# Altium schematic sheet SLA-3000-FPC_Page02.SchDoc
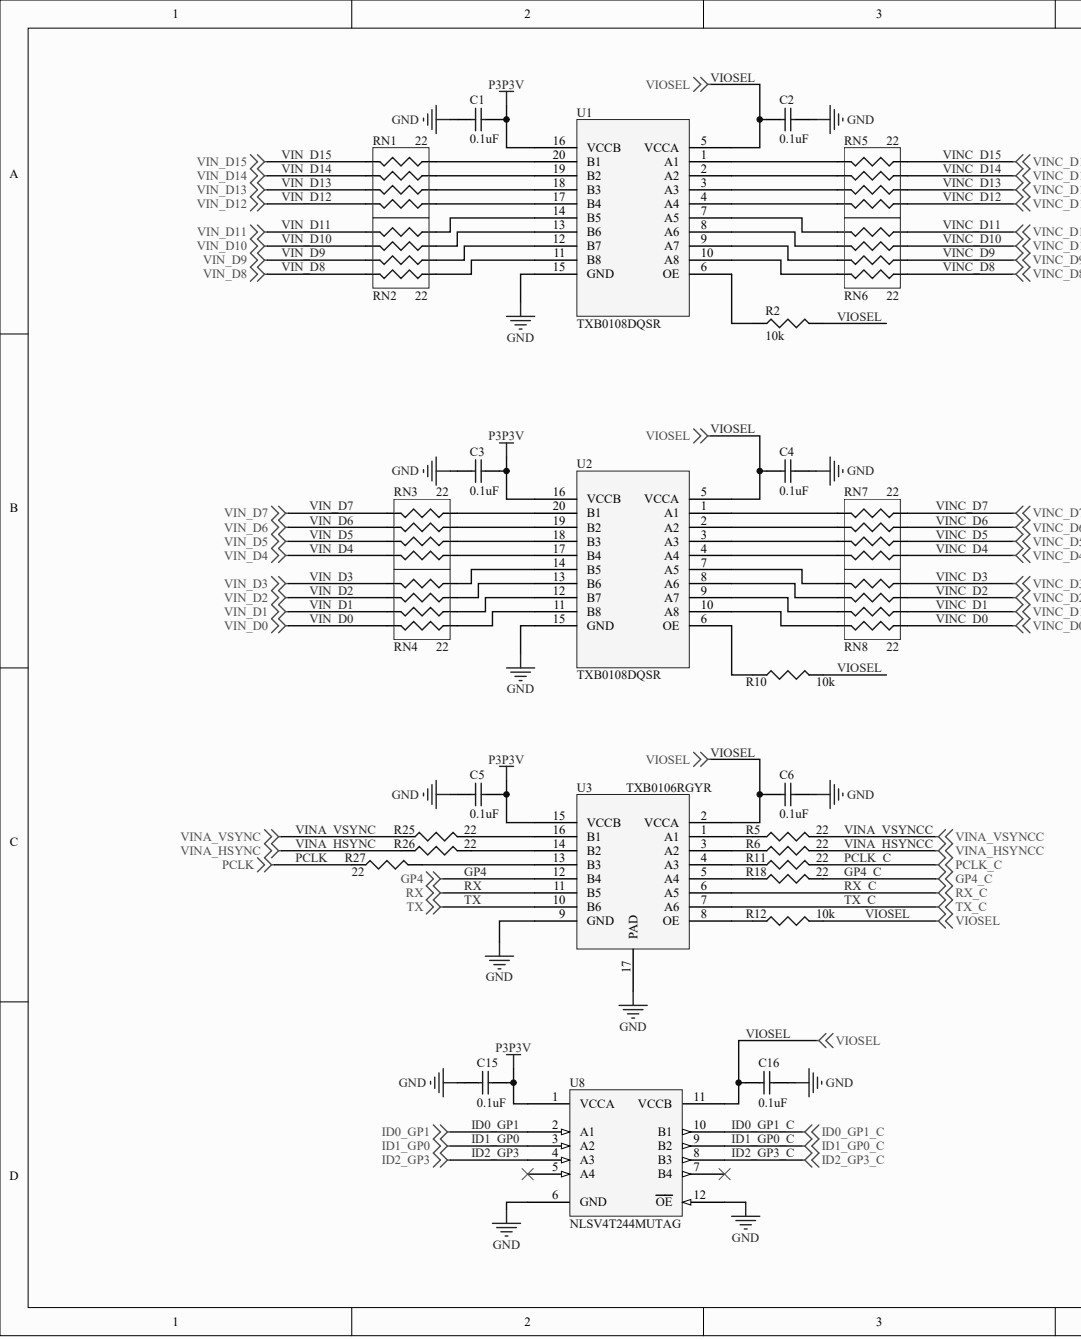
[diagram p0: SLA-3000-FPC_Page02.SchDoc, left]
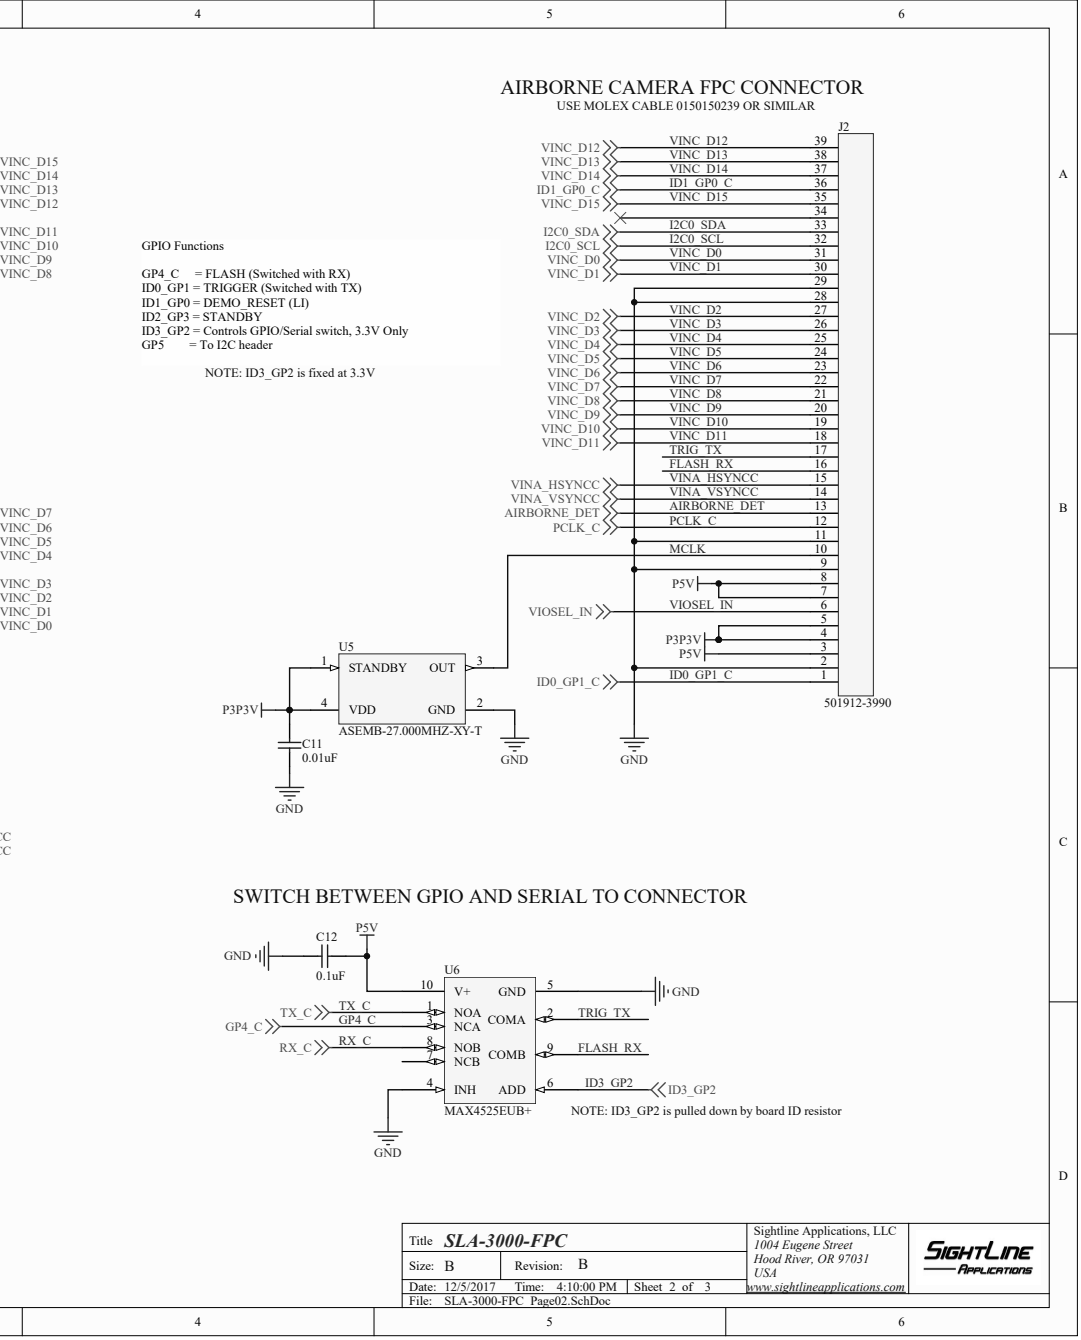
[diagram p1: SLA-3000-FPC_Page02.SchDoc, right]

## Parts
C1  0.1uF  [0402]
C2  0.1uF  [0402]
C3  0.1uF  [0402]
C4  0.1uF  [0402]
C5  0.1uF
C6  0.1uF
C11  0.01uF
C12  0.1uF  [0402]
C15  0.1uF
C16  0.1uF
J2  501912-3990
R2  10k
R5  22  (value not drawn)
R6  22  (value not drawn)
R10  10k  [0402]
R11  22  (value not drawn)
R12  10k
R18  22  (value not drawn)
R25  22  (value not drawn)
R26  22  (value not drawn)
R27  22  (value not drawn)
RN1  22  (value not drawn)
RN2  22  (value not drawn)
RN3  22  (value not drawn)
RN4  22  (value not drawn)
RN5  22  (value not drawn)
RN6  22  (value not drawn)
RN7  22  (value not drawn)
RN8  22  (value not drawn)
U1  TXB0108DQSR
U2  TXB0108DQSR
U3  TXB0106RGYR
U5  ASEMB-27.000MHZ-XY-T
U6  MAX4525EUB+
U8  NLSV4T244MUTAG

## Nets
GND: C1.1 C2.1 C3.1 C4.1 C5.1 C6.1 C11.1 C12.1 C15.1 C16.1 J2.2 J2.9 J2.11 J2.28 J2.29 U1.15(GND) U2.15(GND) U3.9(GND) U3.17(PAD) U5.2(GND) U6.4(INH) U6.5(GND) U8.6(GND) U8.12(OE)
P3P3V: C1.2 C3.2 C5.2 C11.2 C15.2 J2.4 J2.5 U1.16(VCCB) U2.16(VCCB) U3.15(VCCB) U5.1(STANDBY) U5.4(VDD) U8.1(VCCA)
P5V: C12.2 J2.3 J2.7 J2.8 U6.10(V+)
AIRBORNE_DET: J2.13
FLASH_RX: J2.16 U6.9(COMB)
GP4: U3.12(B4)
GP4_C: R18.2 U6.3(NCA)
I2C0_SCL: J2.32
I2C0_SDA: J2.33
ID0_GP1: U8.2(A1)
ID0_GP1_C: J2.1 U8.10(B1)
ID1_GP0: U8.3(A2)
ID1_GP0_C: J2.36 U8.9(B2)
ID2_GP3: U8.4(A3)
ID2_GP3_C: U8.8(B3)
ID3_GP2: U6.6(ADD)
MCLK: J2.10 U5.3(OUT)
PCLK: R27.1
PCLK_C: J2.12 R11.2
RX: U3.11(B5)
RX_C: U3.6(A5) U6.8(NOB)
TRIG_TX: J2.17 U6.2(COMA)
TX: U3.10(B6)
TX_C: U3.7(A6) U6.1(NOA)
VIN_D0: RN4.1
VIN_D1: RN4.2
VIN_D2: RN4.3
VIN_D3: RN4.4
VIN_D4: RN3.1
VIN_D5: RN3.2
VIN_D6: RN3.3
VIN_D7: RN3.4
VIN_D8: RN2.1
VIN_D9: RN2.2
VIN_D10: RN2.3
VIN_D11: RN2.4
VIN_D12: RN1.1
VIN_D13: RN1.2
VIN_D14: RN1.3
VIN_D15: RN1.4
VINA_HSYNC: R26.1
VINA_HSYNCC: J2.15 R6.2
VINA_VSYNC: R25.1
VINA_VSYNCC: J2.14 R5.2
VINC_D0: J2.31 RN8.8
VINC_D1: J2.30 RN8.7
VINC_D2: J2.27 RN8.6
VINC_D3: J2.26 RN8.5
VINC_D4: J2.25 RN7.8
VINC_D5: J2.24 RN7.7
VINC_D6: J2.23 RN7.6
VINC_D7: J2.22 RN7.5
VINC_D8: J2.21 RN6.8
VINC_D9: J2.20 RN6.7
VINC_D10: J2.19 RN6.6
VINC_D11: J2.18 RN6.5
VINC_D12: J2.39 RN5.8
VINC_D13: J2.38 RN5.7
VINC_D14: J2.37 RN5.6
VINC_D15: J2.35 RN5.5
VIOSEL: C2.2 C4.2 C6.2 C16.2 R2.2 R10.2 R12.2 U1.5(VCCA) U2.5(VCCA) U3.2(VCCA) U8.11(VCCB)
VIOSEL_IN: J2.6
NetR2_1: R2.1 U1.6(OE)
NetR5_1: R5.1 U3.1(A1)
NetR6_1: R6.1 U3.3(A2)
NetR10_1: R10.1 U2.6(OE)
NetR11_1: R11.1 U3.4(A3)
NetR12_1: R12.1 U3.8(OE)
NetR18_1: R18.1 U3.5(A4)
NetR25_2: R25.2 U3.16(B1)
NetR26_2: R26.2 U3.14(B2)
NetR27_2: R27.2 U3.13(B3)
NetRN1_5: RN1.5 U1.20(B1)
NetRN1_6: RN1.6 U1.19(B2)
NetRN1_7: RN1.7 U1.18(B3)
NetRN1_8: RN1.8 U1.17(B4)
NetRN2_5: RN2.5 U1.14(B5)
NetRN2_6: RN2.6 U1.13(B6)
NetRN2_7: RN2.7 U1.12(B7)
NetRN2_8: RN2.8 U1.11(B8)
NetRN3_5: RN3.5 U2.20(B1)
NetRN3_6: RN3.6 U2.19(B2)
NetRN3_7: RN3.7 U2.18(B3)
NetRN3_8: RN3.8 U2.17(B4)
NetRN4_5: RN4.5 U2.14(B5)
NetRN4_6: RN4.6 U2.13(B6)
NetRN4_7: RN4.7 U2.12(B7)
NetRN4_8: RN4.8 U2.11(B8)
NetRN5_1: RN5.1 U1.4(A4)
NetRN5_2: RN5.2 U1.3(A3)
NetRN5_3: RN5.3 U1.2(A2)
NetRN5_4: RN5.4 U1.1(A1)
NetRN6_1: RN6.1 U1.10(A8)
NetRN6_2: RN6.2 U1.9(A7)
NetRN6_3: RN6.3 U1.8(A6)
NetRN6_4: RN6.4 U1.7(A5)
NetRN7_1: RN7.1 U2.4(A4)
NetRN7_2: RN7.2 U2.3(A3)
NetRN7_3: RN7.3 U2.2(A2)
NetRN7_4: RN7.4 U2.1(A1)
NetRN8_1: RN8.1 U2.10(A8)
NetRN8_2: RN8.2 U2.9(A7)
NetRN8_3: RN8.3 U2.8(A6)
NetRN8_4: RN8.4 U2.7(A5)

Not connected: J2 34; U6 7(NCB); U8 5(A4) 7(B4)

## Library data of the parts
C1-C4 C12 = (no symbol name): MfgPartNo: C1005X7R1E104K; SLA Part No: SL00150; Rated Voltage: 25V; Tolerance: 10%; Type: Ceramic - X7R; Mfg: TDK; Value: 0.1uF; Capacitance: 0.1uF; Package: 402
C5 C6 C15 C16 = (no symbol name): MfgPartNo: C1005X7R1E104K; SLA Part No: SL00150; Type: Ceramic - X7R; Mfg: TDK; Capacitance: 0.1uF; Package: 402
C11 = (no symbol name): MfgPartNo: GRM155R71C103KA01D; SLA Part No: SL00317; Mfg: Murata; Type: Ceramic - X7R; Capacitance: 0.01uF; Package: 402
J2 = (no symbol name): SLA Part No: SL00670; Gender: Female; Mfg: Molex; MfgPartNo: 501912-3990; Mounting: SMT
R2 R12 = (no symbol name): MfgPartNo: ERJ-2RKF1002X; SLA Part No: SL00225; Mfg: Panasonic; Package: 402; Resistance: 10k
R5 R6 R11 R18 R25-R27 = (no symbol name): MfgPartNo: ERJ-2RKF22R0X; SLA Part No: SL00335; Mfg: Panasonic; Package: 402; Resistance: 22
R10 = (no symbol name): MfgPartNo: ERJ-2RKF1002X; SLA Part No: SL00225; Mfg: Panasonic; Power (W): 1/10; Tolerance: 1%; Value: 10k; Package: 402; Resistance: 10k
RN1-RN8 = (no symbol name): MfgPartNo: YC124-JR-0722RL; SLA Part No: SL00045; Mfg: Yageo; Power: 1/16; Tolerance: 5%; Package: 4x0402; Resistance: 22
U1 U2 = (no symbol name): SLA Part No: SL00416; Mfg: Texas Instruments; HelpURL: http://www.ti.com/lit/ds/symlink/txb0108.pdf; MfgPartNo: TXB0108DQSR; Package: 20-USON
U3 = (no symbol name): SLA Part No: SL00262; Mfg: Texas Instruments; HelpURL: http://www.ti.com/lit/ds/symlink/txb0106.pdf; MfgPartNo: TXB0106RGYR; Package: 16-VQFN
U5 = (no symbol name): SLA Part No: SL00549; Mfg: Abracon; Frequency: 27MHz; MfgPartNo: ASEMB-27.000MHZ-XY-T; Package: ASEMB; Datasheet: http://www.digikey.com/product-detail/en/ASEMB-27.000MHZ-XY-T/535-11127-1-ND/2624353
U6 = (no symbol name): SLA Part No: SL00741; Mfg: Maxim; MfgPartNo: MAX4525EUB+; Package: 10-MSOP; HelpURL: http://datasheets.maximintegrated.com/en/ds/MAX4524-MAX4525.pdf
U8 = (no symbol name): SLA Part No: SL00751; Mfg: ON; MfgPartNo: NLSV4T244MUTAG; Package: 12-UQFN; HelpURL: http://www.onsemi.com/pub/Collateral/NLSV4T244-D.PDF
